# Altium schematic sheet BP6A_EVK_1.SchDoc(BP6A_EVK_1)
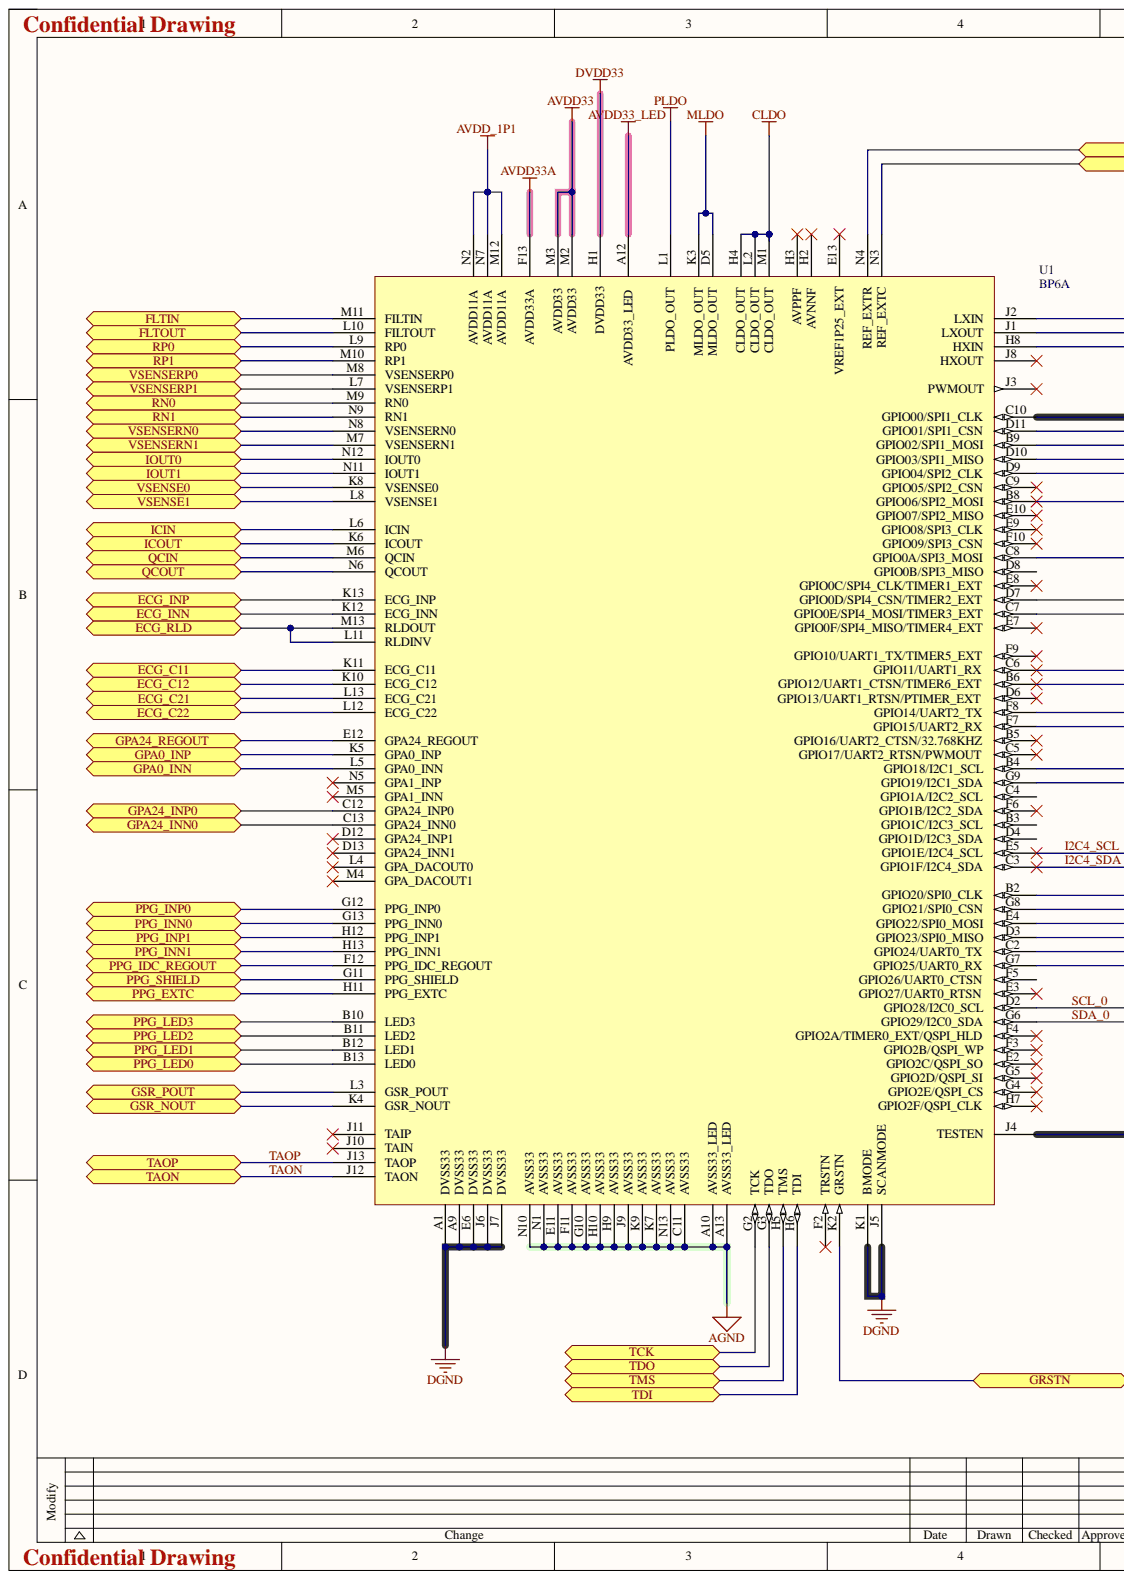
[diagram p0: BP6A_EVK_1.SchDoc, left]
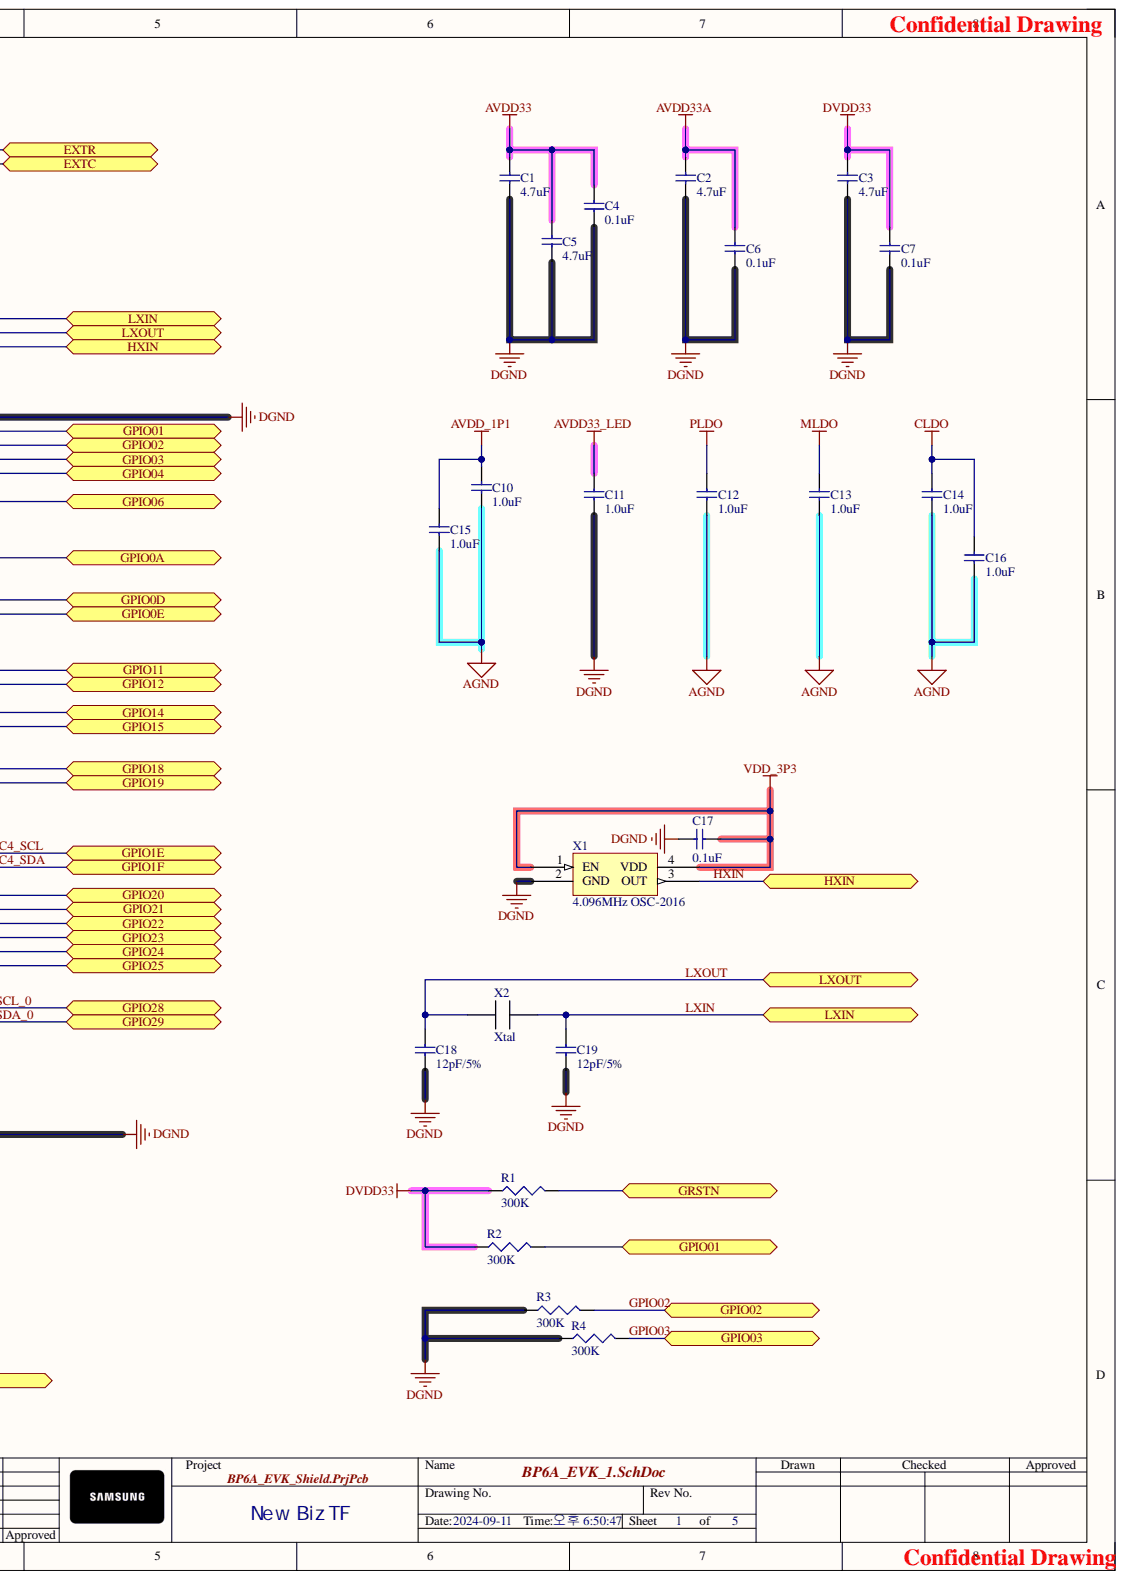
[diagram p1: BP6A_EVK_1.SchDoc, right]

## Parts
C1  4.7uF  [MLCC_1005]
C2  4.7uF  [MLCC_1005]
C3  4.7uF  [MLCC_1005]
C4  0.1uF  [MLCC_1005]
C5  4.7uF  [MLCC_1005]
C6  0.1uF  [MLCC_1005]
C7  0.1uF  [MLCC_1005]
C10  1.0uF  [MLCC_1005]
C11  1.0uF  [MLCC_1005]
C12  1.0uF  [MLCC_1005]
C13  1.0uF  [MLCC_1005]
C14  1.0uF  [MLCC_1005]
C15  1.0uF  [MLCC_1005]
C16  1.0uF  [MLCC_1005]
C17  0.1uF  [MLCC_1005]
C18  12pF/5%  [MLCC_1005]
C19  12pF/5%  [MLCC_1005]
R1  300K  [RES_1005]
R2  300K  [RES_1005]
R3  300K  [RES_1005]
R4  300K  [RES_1005]
U1  BP6A  [S1SBP6A - 065P]
X1  4.096MHz OSC-2016  [SMD_OSC_2016]
X2  Xtal  [SMD_XTAL_1610]

## Ports
ECG_C11, ECG_C12, ECG_C21, ECG_C22, ECG_INN, ECG_INP, ECG_RLD, EXTC, EXTR, FLTIN, FLTOUT, GPA0_INN, GPA0_INP, GPA24_INN0, GPA24_INP0, GPA24_REGOUT, GPIO0A, GPIO0D, GPIO0E, GPIO01, GPIO1E, GPIO1F, GPIO02, GPIO03, GPIO04, GPIO06, GPIO11, GPIO12, GPIO14, GPIO15, GPIO18, GPIO19, GPIO20, GPIO21, GPIO22, GPIO23, GPIO24, GPIO25, GPIO28, GPIO29, GRSTN, GSR_NOUT, GSR_POUT, HXIN, ICIN, ICOUT, IOUT0, IOUT1, LXIN, LXOUT, PPG_EXTC, PPG_IDC_REGOUT, PPG_INN0, PPG_INN1, PPG_INP0, PPG_INP1, PPG_LED0, PPG_LED1, PPG_LED2, PPG_LED3, PPG_SHIELD, QCIN, QCOUT, RN0, RN1, RP0, RP1, TAON, TAOP, TCK, TDI, TDO, TMS, VSENSE0, VSENSERN0, VSENSERN1, VSENSERP0, VSENSERP1, VSENS~{E}1

## Nets
AGND: C10.1 C12.1 C13.1 C14.1 C15.1 C16.1 U1.A10(AVSS33_LED) U1.A13(AVSS33_LED) U1.C11(AVSS33) U1.E11(AVSS33) U1.F11(AVSS33) U1.G10(AVSS33) U1.H9(AVSS33) U1.H10(AVSS33) U1.J9(AVSS33) U1.K7(AVSS33) U1.K9(AVSS33) U1.N1(AVSS33) U1.N10(AVSS33) U1.N13(AVSS33)
AVDD33: C1.2 C4.2 C5.2 U1.M2(AVDD33) U1.M3(AVDD33)
AVDD33_LED: C11.2 U1.A12(AVDD33_LED)
AVDD33A: C2.2 C6.2 U1.F13(AVDD33A)
AVDD_1P1: C10.2 C15.2 U1.M12(AVDD11A) U1.N2(AVDD11A) U1.N7(AVDD11A)
CLDO: C14.2 C16.2 U1.H4(CLDO_OUT) U1.L2(CLDO_OUT) U1.M1(CLDO_OUT)
DGND: C1.1 C2.1 C3.1 C4.1 C5.1 C6.1 C7.1 C11.1 C17.1 C18.1 C19.1 R3.1 R4.1 U1.A1(DVSS33) U1.A9(DVSS33) U1.C10(GPIO00/SPI1_CLK) U1.E6(DVSS33) U1.J4(TESTEN) U1.J5(SCANMODE) U1.J6(DVSS33) U1.J7(DVSS33) U1.K1(BMODE) X1.2(GND)
DVDD33: C3.2 C7.2 R1.1 R2.1 U1.H1(DVDD33)
MLDO: C13.2 U1.D5(MLDO_OUT) U1.K3(MLDO_OUT)
PLDO: C12.2 U1.L1(PLDO_OUT)
VDD_3P3: C17.2 X1.1(EN) X1.4(VDD)
ECG_C11: U1.K11(ECG_C11) ; port ECG_C11
ECG_C12: U1.K10(ECG_C12) ; port ECG_C12
ECG_C21: U1.L13(ECG_C21) ; port ECG_C21
ECG_C22: U1.L12(ECG_C22) ; port ECG_C22
ECG_INN: U1.K12(ECG_INN) ; port ECG_INN
ECG_INP: U1.K13(ECG_INP) ; port ECG_INP
ECG_RLD: U1.L11(RLDINV) U1.M13(RLDOUT) ; port ECG_RLD
EXTC: U1.N3(REF_EXTC) ; port EXTC
EXTR: U1.N4(REF_EXTR) ; port EXTR
FLTIN: U1.M11(FILTIN) ; port FLTIN
FLTOUT: U1.L10(FILTOUT) ; port FLTOUT
GPA0_INN: U1.L5(GPA0_INN) ; port GPA0_INN
GPA0_INP: U1.K5(GPA0_INP) ; port GPA0_INP
GPA24_INN0: U1.C13(GPA24_INN0) ; port GPA24_INN0
GPA24_INP0: U1.C12(GPA24_INP0) ; port GPA24_INP0
GPA24_REGOUT: U1.E12(GPA24_REGOUT) ; port GPA24_REGOUT
GPIO0A: U1.C8(GPIO0A/SPI3_MOSI) ; port GPIO0A
GPIO0D: U1.D7(GPIO0D/SPI4_CSN/TIMER2_EXT) ; port GPIO0D
GPIO0E: U1.C7(GPIO0E/SPI4_MOSI/TIMER3_EXT) ; port GPIO0E
GPIO01: R2.2 U1.D11(GPIO01/SPI1_CSN) ; port GPIO01
GPIO02: R3.2 U1.B9(GPIO02/SPI1_MOSI) ; port GPIO02
GPIO03: R4.2 U1.D10(GPIO03/SPI1_MISO) ; port GPIO03
GPIO04: U1.D9(GPIO04/SPI2_CLK) ; port GPIO04
GPIO06: U1.B8(GPIO06/SPI2_MOSI) ; port GPIO06
GPIO11: U1.C6(GPIO11/UART1_RX) ; port GPIO11
GPIO12: U1.B6(GPIO12/UART1_CTSN/TIMER6_EXT) ; port GPIO12
GPIO14: U1.F8(GPIO14/UART2_TX) ; port GPIO14
GPIO15: U1.F7(GPIO15/UART2_RX) ; port GPIO15
GPIO18: U1.B4(GPIO18/I2C1_SCL) ; port GPIO18
GPIO19: U1.G9(GPIO19/I2C1_SDA) ; port GPIO19
GPIO20: U1.B2(GPIO20/SPI0_CLK) ; port GPIO20
GPIO21: U1.G8(GPIO21/SPI0_CSN) ; port GPIO21
GPIO22: U1.E4(GPIO22/SPI0_MOSI) ; port GPIO22
GPIO23: U1.D3(GPIO23/SPI0_MISO) ; port GPIO23
GPIO24: U1.C2(GPIO24/UART0_TX) ; port GPIO24
GPIO25: U1.G7(GPIO25/UART0_RX) ; port GPIO25
GRSTN: R1.2 U1.K2(GRSTN) ; port GRSTN
GSR_NOUT: U1.K4(GSR_NOUT) ; port GSR_NOUT
GSR_POUT: U1.L3(GSR_POUT) ; port GSR_POUT
HXIN: U1.H8(HXIN) X1.3(OUT) ; port HXIN
I2C4_SCL: U1.E5(GPIO1E/I2C4_SCL) ; port GPIO1E
I2C4_SDA: U1.C3(GPIO1F/I2C4_SDA) ; port GPIO1F
ICIN: U1.L6(ICIN) ; port ICIN
ICOUT: U1.K6(ICOUT) ; port ICOUT
IOUT0: U1.N12(IOUT0) ; port IOUT0
IOUT1: U1.N11(IOUT1) ; port IOUT1
LXIN: C19.2 U1.J2(LXIN) X2.1 ; port LXIN
LXOUT: C18.2 U1.J1(LXOUT) X2.2 ; port LXOUT
PPG_EXTC: U1.H11(PPG_EXTC) ; port PPG_EXTC
PPG_IDC_REGOUT: U1.F12(PPG_IDC_REGOUT) ; port PPG_IDC_REGOUT
PPG_INN0: U1.G13(PPG_INN0) ; port PPG_INN0
PPG_INN1: U1.H13(PPG_INN1) ; port PPG_INN1
PPG_INP0: U1.G12(PPG_INP0) ; port PPG_INP0
PPG_INP1: U1.H12(PPG_INP1) ; port PPG_INP1
PPG_LED0: U1.B13(LED0) ; port PPG_LED0
PPG_LED1: U1.B12(LED1) ; port PPG_LED1
PPG_LED2: U1.B11(LED2) ; port PPG_LED2
PPG_LED3: U1.B10(LED3) ; port PPG_LED3
PPG_SHIELD: U1.G11(PPG_SHIELD) ; port PPG_SHIELD
QCIN: U1.M6(QCIN) ; port QCIN
QCOUT: U1.N6(QCOUT) ; port QCOUT
RN0: U1.M9(RN0) ; port RN0
RN1: U1.N9(RN1) ; port RN1
RP0: U1.L9(RP0) ; port RP0
RP1: U1.M10(RP1) ; port RP1
SCL_0: U1.D2(GPIO28/I2C0_SCL) ; port GPIO28
SDA_0: U1.G6(GPIO29/I2C0_SDA) ; port GPIO29
TAON: U1.J12(TAON) ; port TAON
TAOP: U1.J13(TAOP) ; port TAOP
TCK: U1.G2(TCK) ; port TCK
TDI: U1.H6(TDI) ; port TDI
TDO: U1.G3(TDO) ; port TDO
TMS: U1.H5(TMS) ; port TMS
VSENSE0: U1.K8(VSENSE0) ; port VSENSE0
VSENS~{E}1: U1.L8(VSENSE1) ; port VSENS~{E}1
VSENSERN0: U1.N8(VSENSERN0) ; port VSENSERN0
VSENSERN1: U1.M7(VSENSERN1) ; port VSENSERN1
VSENSERP0: U1.M8(VSENSERP0) ; port VSENSERP0
VSENSERP1: U1.L7(VSENSERP1) ; port VSENSERP1

Not connected: U1 B3(GPIO1C/I2C3_SCL) B5(GPIO16/UART2_CTSN/32.768KHZ) C4(GPIO1A/I2C2_SCL) C5(GPIO17/UART2_RTSN/PWMOUT) C9(GPIO05/SPI2_CSN) D4(GPIO1D/I2C3_SDA) D6(GPIO13/UART1_RTSN/PTIMER_EXT) D8(GPIO0B/SPI3_MISO) D12(GPA24_INP1) D13(GPA24_INN1) E2(GPIO2C/QSPI_SO) E3(GPIO27/UART0_RTSN) E7(GPIO0F/SPI4_MISO/TIMER4_EXT) E8(GPIO0C/SPI4_CLK/TIMER1_EXT) E9(GPIO08/SPI3_CLK) E10(GPIO07/SPI2_MISO) E13(VREF1P25_EXT) F2(TRSTN) F3(GPIO2B/QSPI_WP) F4(GPIO2A/TIMER0_EXT/QSPI_HLD) F5(GPIO26/UART0_CTSN) F6(GPIO1B/I2C2_SDA) F9(GPIO10/UART1_TX/TIMER5_EXT) F10(GPIO09/SPI3_CSN) G4(GPIO2E/QSPI_CS) G5(GPIO2D/QSPI_SI) H2(AVNNF) H3(AVPPF) H7(GPIO2F/QSPI_CLK) J3(PWMOUT) J8(HXOUT) J10(TAIN) J11(TAIP) L4(GPA_DACOUT0) M4(GPA_DACOUT1) M5(GPA1_INN) N5(GPA1_INP)

## Library data of the parts
C1-C7 C10-C16 = MLCC: Component Kind: Standard; Description: MLCC; Library Name: my_components.IntLib
C17-C19 = MLCC_1: 0603MLCC, ±10%; Part Number: C0603-4µ7; Artikkelnr Noca: 20069060; Artikkelnr Simpro: N.A.; Note: 6.3V; Value: 4.7µF; Component Kind: Standard; Footprint Doc: 0603; LatestRevisionDate: 2009-11-23; Pin Count: 2; Published: 2009-05-08; Library Name: pebble.SCHLIB; Publisher: Nordic Semiconductor
R1-R4 = Res: 0603Chip Resistor; Value: 10K; Component Kind: Standard; LatestRevisionDate: 29-May-2009; LatestRevisionNote: IPC-7351 Footprint Added.; PackageDescription: Chip Resistor; PackageReference: J1-0603; Published: 8-Jun-2000; Signal Integrity: Res; Simulation: RESISTOR; Library Name: pebble.SCHLIB; Publisher: Altium Limited
U1 = S1SBP6A: Component Kind: Standard; Description: BP6A; Library Name: my_component.SchLib
X1 = SMD_OSC_2016: Component Kind: Standard; Library Name: my_components.IntLib; LINK: 사급
X2 = SMD_XTAL_1610: SMD_1610; FL P/N: 1SQ3270025; Normal Freq.: 32.768000KHz; Vender: FAITH LONG CRYSTAL; Component Kind: Standard; Library Name: my_component.SchLib; LINK: 사급; Product Type: SMD TUNING FORK 1.6X1.0
